# Altium schematic sheet WiFi Shield Schematic.SchDoc
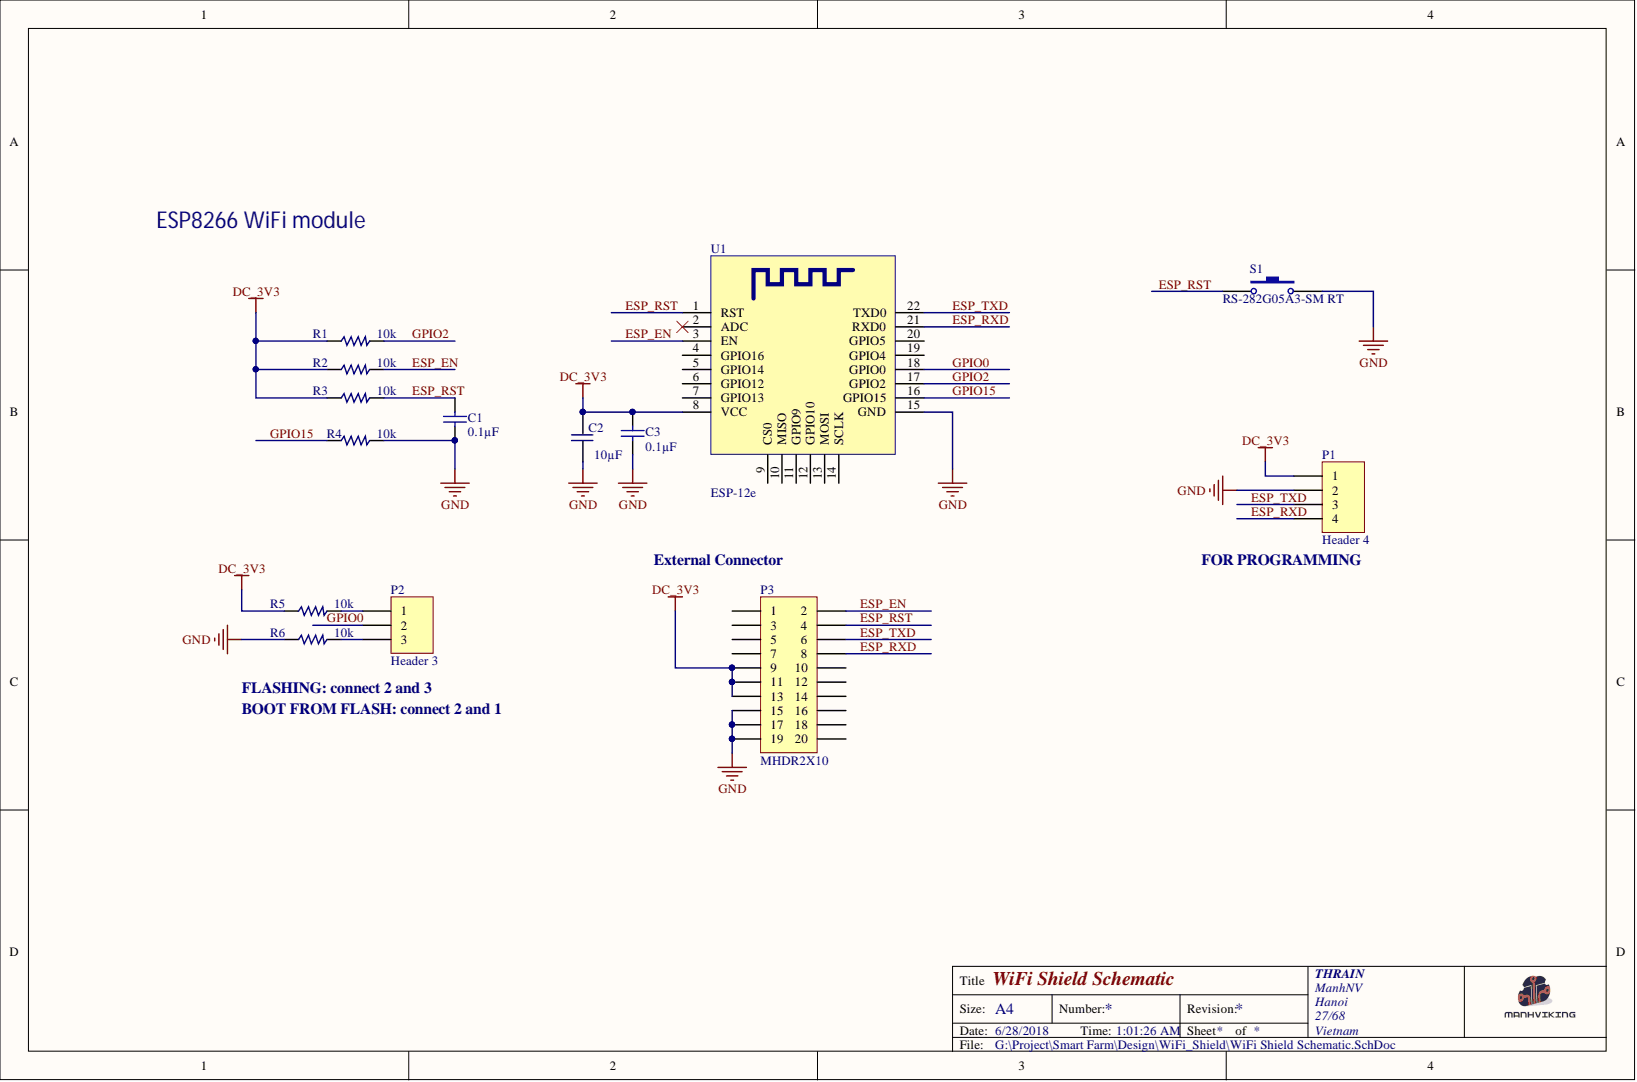
[diagram p0: WiFi Shield Schematic.SchDoc]

## Parts
C1  0.1µF  [C0402M]
C2  10µF  [C0603M]
C3  0.1µF  [C0402M]
P1  Header 4  [HDR1X4]
P2  Header 3  [HDR1X3]
P3  MHDR2X10  [MHDR2X10SMDM]
R1  10k  [R0402M]
R2  10k  [R0402M]
R3  10k  [R0402M]
R4  10k  [R0402M]
R5  10k  [R0402M]
R6  10k  [R0402M]
S1  RS-282G05A3-SM RT  [RS-282G05A3]
U1  ESP-12e  [ESP-12E]

## Nets
DC_3V3: C2.2 C3.1 P1.1 P3.9 P3.11 P3.13 R1.2 R2.2 R3.2 R5.2 U1.8(VCC)
GND: C1.2 C2.1 C3.2 P1.2 P3.15 P3.17 P3.19 R4.1 R6.2 S1.2 U1.15(GND)
ESP_EN: P3.2 R2.1 U1.3(EN)
ESP_RST: C1.1 P3.4 R3.1 S1.1 U1.1(RST)
ESP_RXD: P1.4 P3.8 U1.21(RXD0)
ESP_TXD: P1.3 P3.6 U1.22(TXD0)
GPIO0: P2.2 U1.18(GPIO0)
GPIO2: R1.1 U1.17(GPIO2)
GPIO15: R4.2 U1.16(GPIO15)
NetP2_1: P2.1 R5.1
NetP2_3: P2.3 R6.1

Not connected: P3 1 3 5 7 10 12 14 16 18 20; U1 2(ADC) 4(GPIO16) 5(GPIO14) 6(GPIO12) 7(GPIO13) 9(CS0) 10(MISO) 11(GPIO9) 12(GPIO10) 13(MOSI) 14(SCLK) 19(GPIO4) 20(GPIO5)

## Library data of the parts
C1 C3 = CAPN: Manufacturer: Murata Electronics North America; Manufacturer Part Number: GRM155R61C104KA88J; Notification: Current market demand for these product types have resulted in fluctuating and extending lead times. Lead times may differ.; Temperature Coefficient: X5R; Tolerance: ±10%; Voltage - Rated: 16V; Applications: General Purpose; Category: Capacitors; Component Kind: Standard; ComponentLink1Description: https://www.murata.com/~/media/webrenewal/support/library/catalog/products/capacitor/mlcc/c02e.ashx; ComponentLink1URL: https://www.murata.com/~/media/webrenewal/support/library/catalog/products/capacitor/mlcc/c02e.ashx; Features: -; Height - Seated (Max): -; Lead Spacing: -; Mounting Type: Surface Mount, MLCC; Operating Temperature: -55°C ~ 85°C; Package / Case: 0402 (1005 Metric); Packaging: Cut Tape (CT); Part Status: Active; Pricing 1: 1=0.1, 10=0.035, 100=0.0155, 500=0.01106, 1000=0.0087, 2500=0.00791, 5000=0.00727 (USD); Ratings: -; RoHS: RoHS Compliant; Size / Dimension: 0.039" L x 0.020" W (1.00mm x 0.50mm); Stock 1: 500000; Supplier 1: Digi-Key; Supplier Part Number 1: 490-6310-1-ND; Thickness (Max): 0.022" (0.55mm); Library Name: blueThermoSchlib.SchLib
C2 = CAPSMD: CAP CER 10UF 10V X5R 0603; Manufacturer: Taiyo Yuden; Manufacturer Part Number: LMK107BBJ106MAHT; Tolerance: ±20%; Applications: Automotive; Capacitance: 10µF; Category: Capacitors; Component Kind: Standard; ComponentLink1Description: http://ds.yuden.co.jp/TYCOMPAS/ut/detail.do?productNo=LMK107BBJ106MAHT&fileName=LMK107BBJ106MAHT_SS&mode=specSheetDownload; ComponentLink1URL: http://ds.yuden.co.jp/TYCOMPAS/ut/detail.do?productNo=LMK107BBJ106MAHT&fileName=LMK107BBJ106MAHT_SS&mode=specSheetDownload; Features: -; Height - Seated (Max): -; Lead Spacing: -; Lead Style: -; Library Name: BLE.SchLib; Mounting Type: Surface Mount, MLCC; Operating Temperature: -55°C ~ 85°C; Package / Case: 0603 (1608 Metric); Packaging: Cut Tape (CT); Part Status: Active; Pricing 1: 1=0.44, 10=0.309, 100=0.1852, 500=0.1389, 1000=0.11729 (USD); Ratings: AEC-Q200; RoHS: RoHS Compliant; Size / Dimension: 0.063" L x 0.032" W (1.60mm x 0.80mm); Stock 1: 455125; Supplier 1: Digi-Key; Supplier Part Number 1: 587-3412-1-ND; Temperature Coefficient: X5R; Thickness (Max): 0.035" (0.90mm); Voltage - Rated: 10V
P1 = Header 4: Header, 4-Pin; Component Kind: Standard; LatestRevisionDate: 17-Jul-2002; LatestRevisionNote: Re-released for DXP Platform.; Library Name: Miscellaneous Connectors.IntLib; Signal Integrity: Connector; Publisher: Altium Limited
P2 = Header 3: Header, 3-Pin; Component Kind: Standard; LatestRevisionDate: 17-Jul-2002; LatestRevisionNote: Re-released for DXP Platform.; Library Name: Miscellaneous Connectors.IntLib; Signal Integrity: Connector; Publisher: Altium Limited
P3 = MHDR2X10: Header, 10-Pin, Dual row; Component Kind: Standard; LatestRevisionDate: 17-Jul-2002; LatestRevisionNote: Re-released for DXP Platform.; Signal Integrity: Connector; Library Name: BinhLib.IntLib; Publisher: Altium Limited
R1-R6 = RES_SMD: RES SMD 10K OHM 1% 1/16W 0402; Manufacturer: Vishay Dale; Manufacturer Part Number: CRCW040210K0FKEDC; Power (Watts): 0.063W, 1/16W; Temperature Coefficient: ±100ppm/°C; Category: Resistors; Component Kind: Standard; ComponentLink1Description: http://www.vishay.com/docs/28773/crcwce3.pdf; ComponentLink1URL: http://www.vishay.com/docs/28773/crcwce3.pdf; Composition: Thick Film; Failure Rate: -; Features: -; Height - Seated (Max): 0.014" (0.35mm); Library Name: BLE.SchLib; Operating Temperature: -55°C ~ 155°C; Package / Case: 0402 (1005 Metric); Packaging: Cut Tape (CT); Part Status: Active; Pricing 1: 1=0.1, 10=0.026, 100=0.0104, 1000=0.00466, 2500=0.00405, 5000=0.00334 (USD); RoHS: RoHS Compliant; Size / Dimension: 0.039" L x 0.020" W (1.00mm x 0.50mm); Stock 1: 336311; Supplier 1: Digi-Key; Supplier Device Package: 0402; Supplier Part Number 1: 541-3959-1-ND; Tolerance: ±1%
S1 = SW-PB: SWITCH TACTILE SPST-NO 0.05A 12V; Manufacturer: C&K; Actuator Height off PCB, Vertical: 2.50mm; Actuator Material: Polyamide (PA), Nylon; Actuator Orientation: Top Actuated; Actuator Type: Rectangular Button; Contact Rating @ Voltage: 0.05A @ 12VDC; Illumination: Non-Illuminated; Mechanical Life: 50,000 Cycles; Operating Force: 250gf; Outline: 6.00mm x 3.60mm; Switch Function: Off-Mom; Switch Travel: 0.25mm; Actuator Length, Right Angle: -; Category: Switches; Circuit: SPST-NO; Component Kind: Standard; ComponentLink1Description: https://media.digikey.com/pdf/Data%20Sheets/C&K/RS-282G05A-SM_RT.pdf; ComponentLink1URL: https://media.digikey.com/pdf/Data%20Sheets/C&K/RS-282G05A-SM_RT.pdf; Features: -; Illumination Type, Color: -; Illumination Voltage (Nominal): -; Ingress Protection: -; Library Name: Miscellaneous Devices.IntLib; Manufacturer Part Number: RS-282G05A3-SM RT; Mounting Type: Surface Mount; Operating Temperature: -; Packaging: Cut Tape (CT); Part Status: Active; Pricing 1: 1=0.81, 10=0.78, 25=0.7356, 50=0.6474, 100=0.5885, 250=0.55908, 500=0.54436, 1000=0.42666 (USD); RoHS: RoHS Compliant; Stock 1: 55078; Supplier 1: Digi-Key; Supplier Part Number 1: CKN10384CT-ND; Termination Style: Gull Wing
U1 = ESP-12e: ESP8266 SMT MODULE; Manufacturer: Adafruit Industries LLC; Manufacturer Part Number: 2491; Antenna Type: Integrated, Trace; Current - Receiving: 50mA ~ 56mA; Current - Transmitting: 120mA ~ 170mA; Data Rate: 72.2Mbps; Frequency: 2.4GHz; Power - Output: 20.5dBm; Protocol: 802.11b/g/n; RF Family/Standard: WiFi; Sensitivity: -98dBm; Serial Interfaces: I²C, I²S, SPI, UART; Voltage - Supply: 3 V ~ 3.6 V; Category: RF/IF and RFID; Component Kind: Standard; ComponentLink1Description: https://www.adafruit.com/images/product-files/2471/0A-ESP8266__Datasheet__EN_v4.3.pdf; ComponentLink1URL: https://www.adafruit.com/images/product-files/2471/0A-ESP8266__Datasheet__EN_v4.3.pdf; Library Name: BLE.SchLib; Memory Size: -; Modulation: -; Mounting Type: Surface Mount; Operating Temperature: -40°C ~ 125°C; Package / Case: Module; Packaging: Bulk; Part Status: Obsolete; Pricing 1: 1=6.95 (USD); RoHS: RoHS Compliant; Stock 1: 493; Supplier 1: Digi-Key; Supplier Part Number 1: 1528-1438-ND; Utilized IC / Part: ESP8266
